# Altium schematic sheet 05led.SchDoc
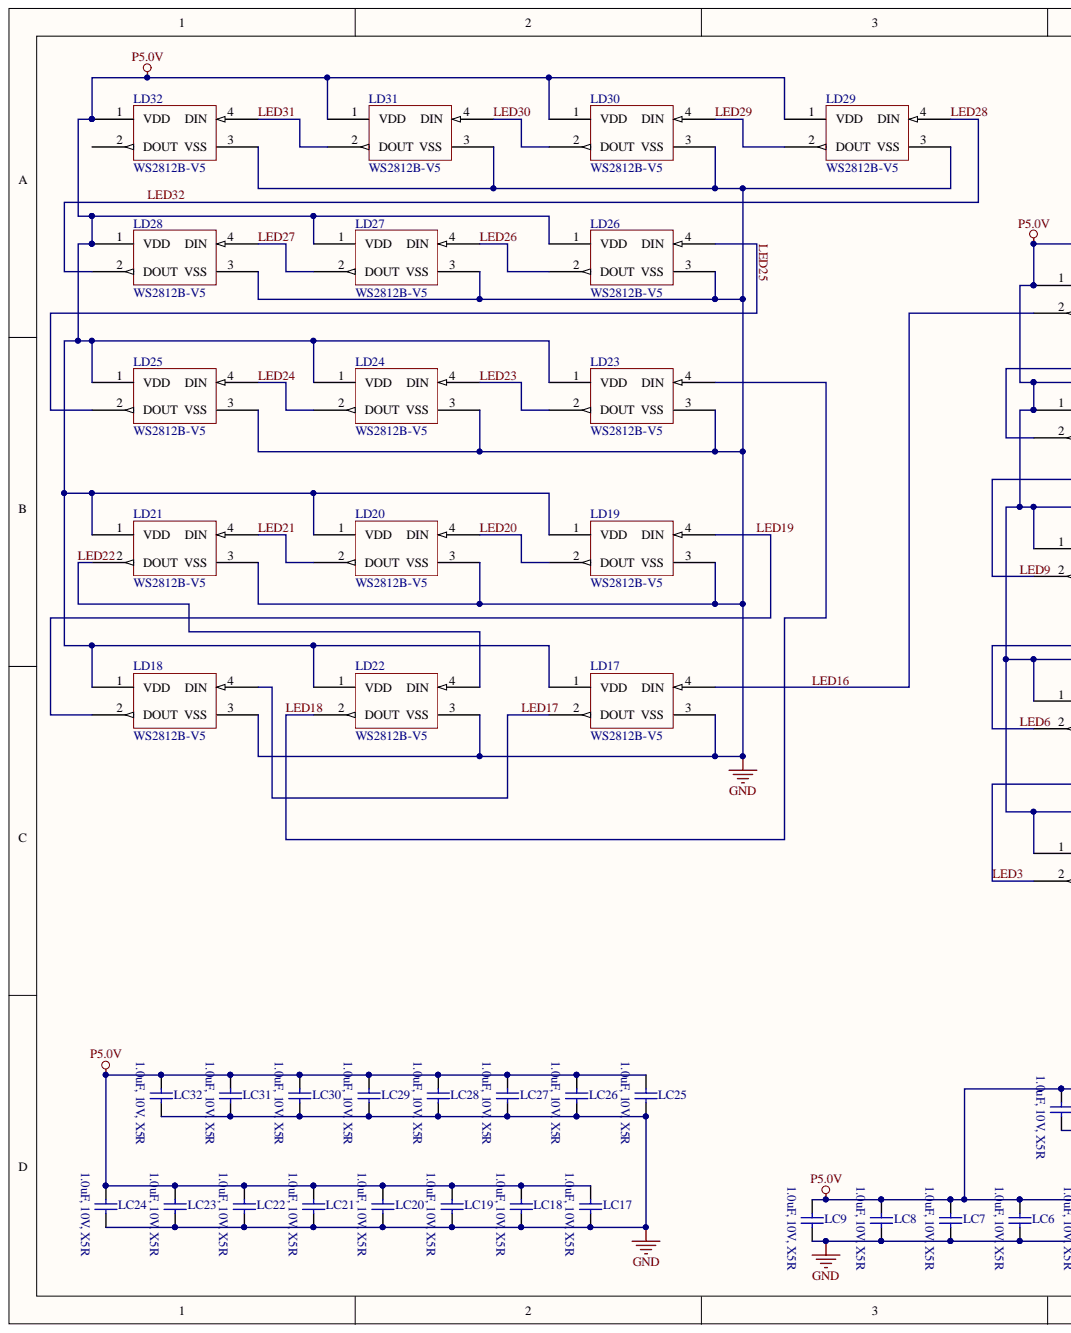
[diagram p0: 05led.SchDoc, left]
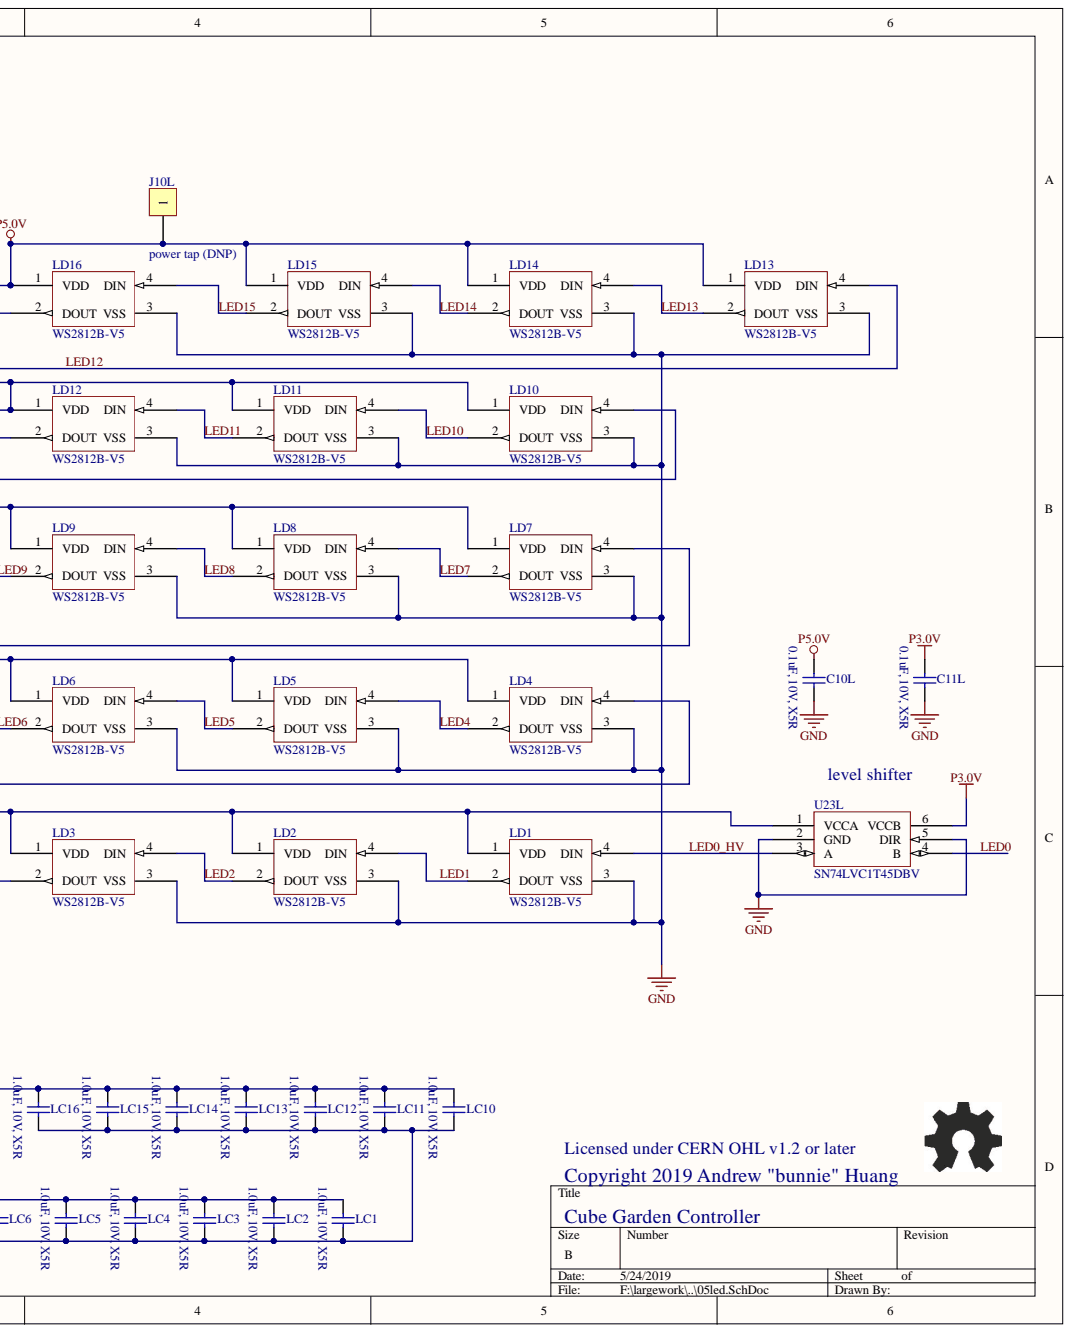
[diagram p1: 05led.SchDoc, right]

## Parts
C10L  0.1uF, 10V, X5R  [B_CAP0402]
C11L  0.1uF, 10V, X5R  [B_CAP0402]
J10L  power tap (DNP)  [RESTAP-0603]
LC1  1.0uF, 10V, X5R  [B_CAP0402]
LC2  1.0uF, 10V, X5R  [B_CAP0402]
LC3  1.0uF, 10V, X5R  [B_CAP0402]
LC4  1.0uF, 10V, X5R  [B_CAP0402]
LC5  1.0uF, 10V, X5R  [B_CAP0402]
LC6  1.0uF, 10V, X5R  [B_CAP0402]
LC7  1.0uF, 10V, X5R  [B_CAP0402]
LC8  1.0uF, 10V, X5R  [B_CAP0402]
LC9  1.0uF, 10V, X5R  [B_CAP0402]
LC10  1.0uF, 10V, X5R  [B_CAP0402]
LC11  1.0uF, 10V, X5R  [B_CAP0402]
LC12  1.0uF, 10V, X5R  [B_CAP0402]
LC13  1.0uF, 10V, X5R  [B_CAP0402]
LC14  1.0uF, 10V, X5R  [B_CAP0402]
LC15  1.0uF, 10V, X5R  [B_CAP0402]
LC16  1.0uF, 10V, X5R  [B_CAP0402]
LC17  1.0uF, 10V, X5R  [B_CAP0402]
LC18  1.0uF, 10V, X5R  [B_CAP0402]
LC19  1.0uF, 10V, X5R  [B_CAP0402]
LC20  1.0uF, 10V, X5R  [B_CAP0402]
LC21  1.0uF, 10V, X5R  [B_CAP0402]
LC22  1.0uF, 10V, X5R  [B_CAP0402]
LC23  1.0uF, 10V, X5R  [B_CAP0402]
LC24  1.0uF, 10V, X5R  [B_CAP0402]
LC25  1.0uF, 10V, X5R  [B_CAP0402]
LC26  1.0uF, 10V, X5R  [B_CAP0402]
LC27  1.0uF, 10V, X5R  [B_CAP0402]
LC28  1.0uF, 10V, X5R  [B_CAP0402]
LC29  1.0uF, 10V, X5R  [B_CAP0402]
LC30  1.0uF, 10V, X5R  [B_CAP0402]
LC31  1.0uF, 10V, X5R  [B_CAP0402]
LC32  1.0uF, 10V, X5R  [B_CAP0402]
LD1  WS2812B-V5  [WS2812B]
LD2  WS2812B-V5  [WS2812B]
LD3  WS2812B-V5  [WS2812B]
LD4  WS2812B-V5  [WS2812B]
LD5  WS2812B-V5  [WS2812B]
LD6  WS2812B-V5  [WS2812B]
LD7  WS2812B-V5  [WS2812B]
LD8  WS2812B-V5  [WS2812B]
LD9  WS2812B-V5  [WS2812B]
LD10  WS2812B-V5  [WS2812B]
LD11  WS2812B-V5  [WS2812B]
LD12  WS2812B-V5  [WS2812B]
LD13  WS2812B-V5  [WS2812B]
LD14  WS2812B-V5  [WS2812B]
LD15  WS2812B-V5  [WS2812B]
LD16  WS2812B-V5  [WS2812B]
LD17  WS2812B-V5  [WS2812B]
LD18  WS2812B-V5  [WS2812B]
LD19  WS2812B-V5  [WS2812B]
LD20  WS2812B-V5  [WS2812B]
LD21  WS2812B-V5  [WS2812B]
LD22  WS2812B-V5  [WS2812B]
LD23  WS2812B-V5  [WS2812B]
LD24  WS2812B-V5  [WS2812B]
LD25  WS2812B-V5  [WS2812B]
LD26  WS2812B-V5  [WS2812B]
LD27  WS2812B-V5  [WS2812B]
LD28  WS2812B-V5  [WS2812B]
LD29  WS2812B-V5  [WS2812B]
LD30  WS2812B-V5  [WS2812B]
LD31  WS2812B-V5  [WS2812B]
LD32  WS2812B-V5  [WS2812B]
U23L  SN74LVC1T45DBV  [SOT26A-6N]

## Nets
GND: C10L.2 C11L.2 LC1.2 LC2.2 LC3.2 LC4.2 LC5.2 LC6.2 LC7.2 LC8.2 LC9.2 LC10.2 LC11.2 LC12.2 LC13.2 LC14.2 LC15.2 LC16.2 LC17.2 LC18.2 LC19.2 LC20.2 LC21.2 LC22.2 LC23.2 LC24.2 LC25.2 LC26.2 LC27.2 LC28.2 LC29.2 LC30.2 LC31.2 LC32.2 LD1.3(VSS) LD2.3(VSS) LD3.3(VSS) LD4.3(VSS) LD5.3(VSS) LD6.3(VSS) LD7.3(VSS) LD8.3(VSS) LD9.3(VSS) LD10.3(VSS) LD11.3(VSS) LD12.3(VSS) LD13.3(VSS) LD14.3(VSS) LD15.3(VSS) LD16.3(VSS) LD17.3(VSS) LD18.3(VSS) LD19.3(VSS) LD20.3(VSS) LD21.3(VSS) LD22.3(VSS) LD23.3(VSS) LD24.3(VSS) LD25.3(VSS) LD26.3(VSS) LD27.3(VSS) LD28.3(VSS) LD29.3(VSS) LD30.3(VSS) LD31.3(VSS) LD32.3(VSS) U23L.2(GND) U23L.5(DIR)
P3.0V: C11L.1 U23L.6(VCCB)
P5.0V: C10L.1 J10L.1 LC1.1 LC2.1 LC3.1 LC4.1 LC5.1 LC6.1 LC7.1 LC8.1 LC9.1 LC10.1 LC11.1 LC12.1 LC13.1 LC14.1 LC15.1 LC16.1 LC17.1 LC18.1 LC19.1 LC20.1 LC21.1 LC22.1 LC23.1 LC24.1 LC25.1 LC26.1 LC27.1 LC28.1 LC29.1 LC30.1 LC31.1 LC32.1 LD1.1(VDD) LD2.1(VDD) LD3.1(VDD) LD4.1(VDD) LD5.1(VDD) LD6.1(VDD) LD7.1(VDD) LD8.1(VDD) LD9.1(VDD) LD10.1(VDD) LD11.1(VDD) LD12.1(VDD) LD13.1(VDD) LD14.1(VDD) LD15.1(VDD) LD16.1(VDD) LD17.1(VDD) LD18.1(VDD) LD19.1(VDD) LD20.1(VDD) LD21.1(VDD) LD22.1(VDD) LD23.1(VDD) LD24.1(VDD) LD25.1(VDD) LD26.1(VDD) LD27.1(VDD) LD28.1(VDD) LD29.1(VDD) LD30.1(VDD) LD31.1(VDD) LD32.1(VDD) U23L.1(VCCA)
LED0: U23L.4(B)
LED0_HV: LD1.4(DIN) U23L.3(A)
LED1: LD1.2(DOUT) LD2.4(DIN)
LED2: LD2.2(DOUT) LD3.4(DIN)
LED3: LD3.2(DOUT) LD4.4(DIN)
LED4: LD4.2(DOUT) LD5.4(DIN)
LED5: LD5.2(DOUT) LD6.4(DIN)
LED6: LD6.2(DOUT) LD7.4(DIN)
LED7: LD7.2(DOUT) LD8.4(DIN)
LED8: LD8.2(DOUT) LD9.4(DIN)
LED9: LD9.2(DOUT) LD10.4(DIN)
LED10: LD10.2(DOUT) LD11.4(DIN)
LED11: LD11.2(DOUT) LD12.4(DIN)
LED12: LD12.2(DOUT) LD13.4(DIN)
LED13: LD13.2(DOUT) LD14.4(DIN)
LED14: LD14.2(DOUT) LD15.4(DIN)
LED15: LD15.2(DOUT) LD16.4(DIN)
LED16: LD16.2(DOUT) LD17.4(DIN)
LED17: LD17.2(DOUT) LD18.4(DIN)
LED18: LD22.2(DOUT) LD23.4(DIN)
LED19: LD18.2(DOUT) LD19.4(DIN)
LED20: LD19.2(DOUT) LD20.4(DIN)
LED21: LD20.2(DOUT) LD21.4(DIN)
LED22: LD21.2(DOUT) LD22.4(DIN)
LED23: LD23.2(DOUT) LD24.4(DIN)
LED24: LD24.2(DOUT) LD25.4(DIN)
LED25: LD25.2(DOUT) LD26.4(DIN)
LED26: LD26.2(DOUT) LD27.4(DIN)
LED27: LD27.2(DOUT) LD28.4(DIN)
LED28: LD28.2(DOUT) LD29.4(DIN)
LED29: LD29.2(DOUT) LD30.4(DIN)
LED30: LD30.2(DOUT) LD31.4(DIN)
LED31: LD31.2(DOUT) LD32.4(DIN)

Not connected: LD32 2(DOUT)

## Library data of the parts
C10L C11L = Cap Semi: Capacitor (Semiconductor SIM Model); MPN: CL05A104KP5NNNC; MYPN: C-104-10-X5R-0402; VENDOR: Samsung; Component Kind: Standard; Library Name: Miscellaneous Devices.IntLib; Signal Integrity: Cap Semi; Simulation: CAP
J10L = CON1: Connector; MYPN: J-TP-PWRTAP-0603; Component Kind: Standard; MPN: DNP; VENDOR: DNP
LC1-LC32 = Cap Semi: Capacitor (Semiconductor SIM Model); MPN: CL05A105KP5NNND; MYPN: C-105-10-X5R-0402; VENDOR: Samsung; Component Kind: Standard; Library Name: Miscellaneous Devices.IntLib; Signal Integrity: Cap Semi; Simulation: CAP
LD1-LD32 = WS2812B: RGB LED with integrated controller IC; MYPN: UWS2812B; VENDOR: Worldsemi; Component Kind: Standard; Library Name: commonsch.lib; MPN: WS2812B-V5
U23L = SN74LVC1T45: Single-gate level translator; MPN: SN74LVC1T45DBVT; MYPN: USN74LVC1T45DBV; VENDOR: Texas Instruments; Component Kind: Standard; Library Name: commonsch.lib
